# Altium schematic sheet PicoEco_top.SchDoc
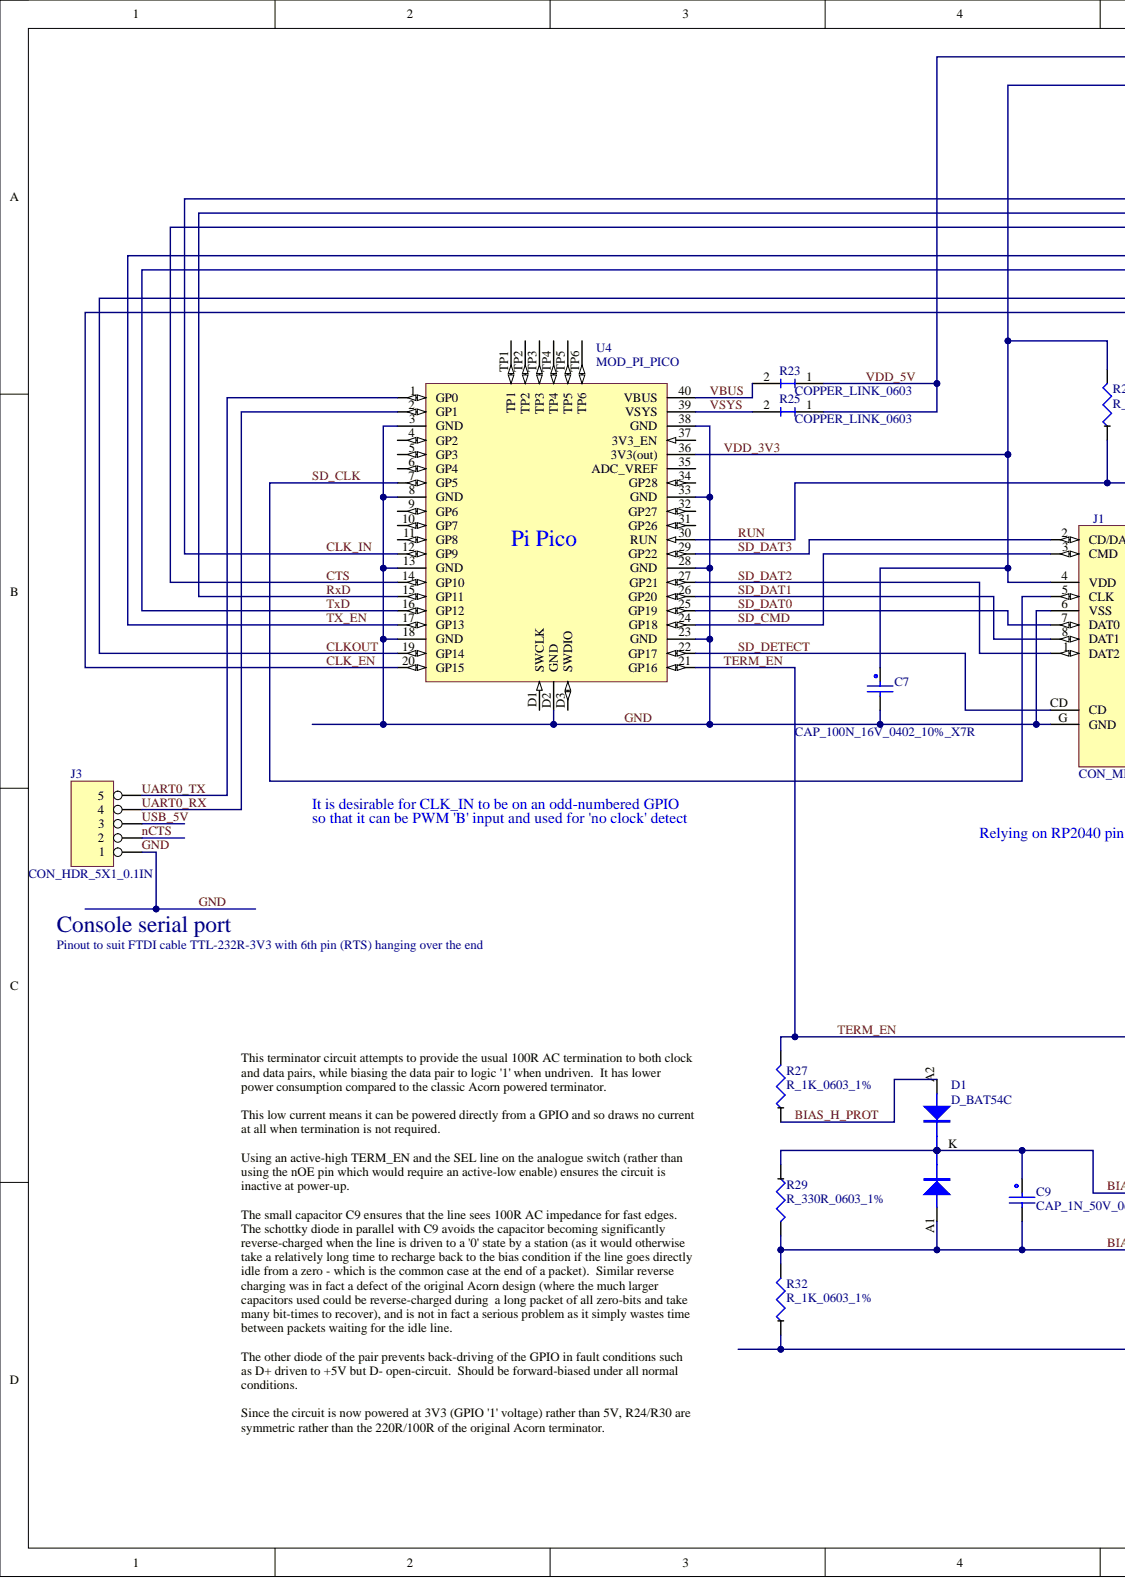
[diagram p0: PicoEco_top.SchDoc, left]
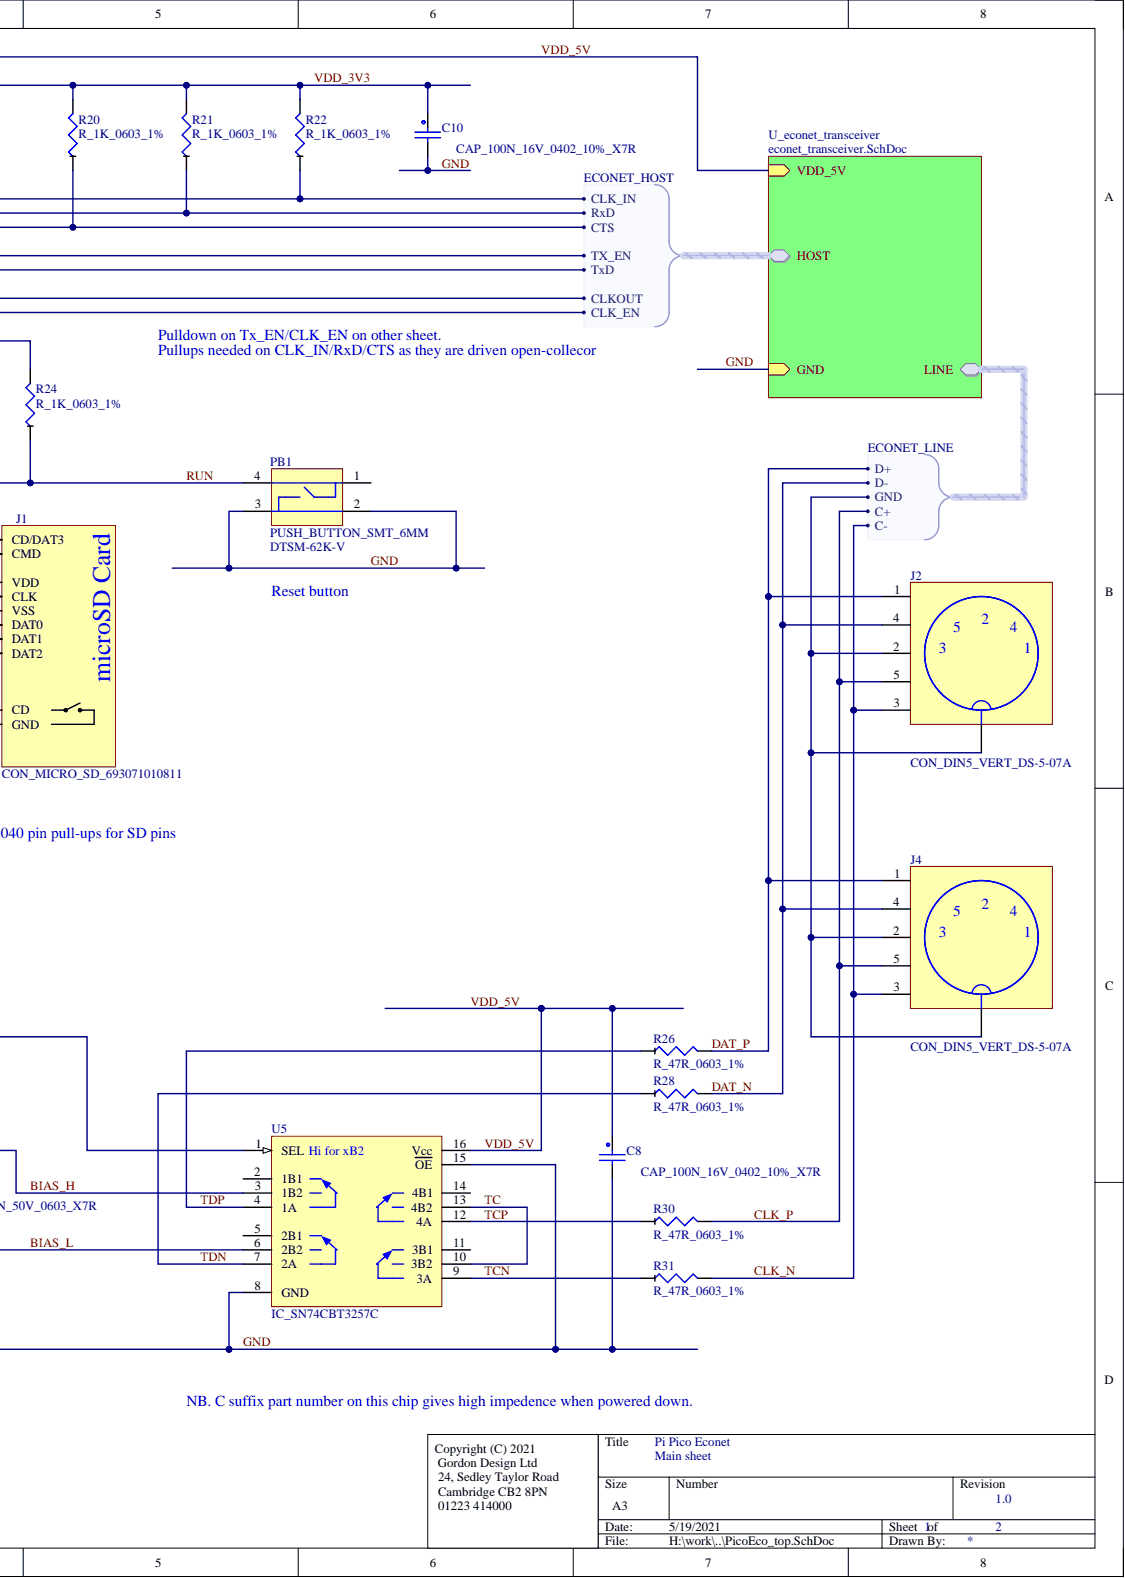
[diagram p1: PicoEco_top.SchDoc, right]

## Parts
C7  CAP_100N_16V_0402_10%_X7R  [C_0402]
C8  CAP_100N_16V_0402_10%_X7R  [C_0402]
C9  CAP_1N_50V_0603_X7R  [C_0603]
C10  CAP_100N_16V_0402_10%_X7R  [C_0402]
D1  D_BAT54C  [SOT23_BAT54C]
J1  CON_MICRO_SD_693071010811  [CON_MICROSD_693071020811]  (value not drawn)
J2  CON_DIN5_VERT_DS-5-07A  [CON_DIN5_VERT_COMBO3]
J3  CON_HDR_5X1_0.1IN  [CON_HDR_5X1]
J4  CON_DIN5_VERT_DS-5-07A  [CON_DIN5_VERT_COMBO3]
PB1  PUSH_BUTTON_SMT_6MM  PARTNO: DTSM-62K-V  [PUSH_BUTTON_SMT_6MM_DTSM-62K]
R20  R_1K_0603_1%  [R_0603]
R21  R_1K_0603_1%  [R_0603]
R22  R_1K_0603_1%  [R_0603]
R23  COPPER_LINK_0603  [COPPER_LINK_0603]  (value not drawn)
R24  R_1K_0603_1%  [R_0603]
R25  COPPER_LINK_0603  [COPPER_LINK_0603]  (value not drawn)
R26  R_47R_0603_1%  [R_0603]
R27  R_1K_0603_1%  [R_0603]
R28  R_47R_0603_1%  [R_0603]
R29  R_330R_0603_1%  [R_0603]
R30  R_47R_0603_1%  [R_0603]
R31  R_47R_0603_1%  [R_0603]
R32  R_1K_0603_1%  [R_0603]
U4  MOD_PI_PICO  [MOD_PI_PICO_SPECIAL]
U5  IC_SN74CBT3257C  [IC_TSSOP16_TI_PKG_PW]

## Nets
BIAS_H: C9.1 D1.K R29.1 U5.3(1B2)
BIAS_H_PROT: D1.A2 R27.1
BIAS_L: C9.2 D1.A1 R29.2 R32.2 U5.6(2B2)
CLK_EN: U4.20(GP15)
CLK_IN: R22.1 U4.12(GP9)
CLK_N: J2.3 J4.3 R31.2
CLK_P: J2.5 J4.5 R30.2
CLKOUT: U4.19(GP14)
CTS: R20.1 U4.14(GP10)
DAT_N: J2.4 J4.4 R28.2
DAT_P: J2.1 J4.1 R26.2
GND: C7.2 C8.2 C10.2 J1.6(VSS) J1.G(GND) J3.1 PB1.2 PB1.3 R32.1 U4.3(GND) U4.8(GND) U4.13(GND) U4.18(GND) U4.23(GND) U4.28(GND) U4.33(GND) U4.38(GND) U4.D2(GND) U5.8(GND) U5.15(OE)
nCTS: J3.2
RUN: PB1.4 R24.1 U4.30(RUN)
RxD: R21.1 U4.15(GP11)
SD_CLK: J1.5(CLK) U4.7(GP5)
SD_CMD: J1.3(CMD) U4.24(GP18)
SD_DAT0: J1.7(DAT0) U4.25(GP19)
SD_DAT1: J1.8(DAT1) U4.26(GP20)
SD_DAT2: J1.1(DAT2) U4.27(GP21)
SD_DAT3: J1.2(CD/DAT3) U4.29(GP22)
SD_DETECT: J1.CD U4.22(GP17)
TC: U5.10(3B2) U5.13(4B2)
TCN: R31.1 U5.9(3A)
TCP: R30.1 U5.12(4A)
TDN: R28.1 U5.7(2A)
TDP: R26.1 U5.4(1A)
TERM_EN: R27.2 U4.21(GP16) U5.1(SEL_Hi_for_xB2)
TX_EN: U4.17(GP13)
TxD: U4.16(GP12)
UART0_RX: J3.4 U4.2(GP1)
UART0_TX: J3.5 U4.1(GP0)
USB_5V: J3.3
VBUS: R23.2 U4.40(VBUS)
VDD_3V3: C7.1 C10.1 J1.4(VDD) R20.2 R21.2 R22.2 R24.2 U4.36(3V3(out))
VDD_5V: C8.1 R23.1 R25.1 U5.16(Vcc)
VSYS: R25.2 U4.39(VSYS)
NetJ2_2: J2.2 J2.SHLD J4.2 J4.SHLD
NetPB1_1: PB1.1
NetU4_4: U4.4(GP2)
NetU4_5: U4.5(GP3)
NetU4_6: U4.6(GP4)
NetU4_9: U4.9(GP6)
NetU4_10: U4.10(GP7)
NetU4_11: U4.11(GP8)
NetU4_31: U4.31(GP26)
NetU4_32: U4.32(GP27)
NetU4_34: U4.34(GP28)
NetU4_35: U4.35(ADC_VREF)
NetU4_37: U4.37(3V3_EN)
NetU4_D1: U4.D1(SWCLK)
NetU4_D3: U4.D3(SWDIO)
NetU4_TP1: U4.TP1
NetU4_TP2: U4.TP2
NetU4_TP3: U4.TP3
NetU4_TP4: U4.TP4
NetU4_TP5: U4.TP5
NetU4_TP6: U4.TP6
NetU5_2: U5.2(1B1)
NetU5_5: U5.5(2B1)
NetU5_11: U5.11(3B1)
NetU5_14: U5.14(4B1)

## Library data of the parts
C7 C8 C10 = CAP_100N_16V_0402_10%_X7R: Capacitor 100nF 16V, 0603, X7R dielectric, 10% tolerance; MANUF: TDK; PARTNO: CGA2B1X7R1C104K050BC; PARTNO_NOTES: Generic part - other manuf acceptable; RS: 723-5200P; Component Kind: Standard; Digikey: 445-5613-1-ND; FARNELL: 2310530; Mouser: 810-CGA2B1X7R1C104K; Library Name: Arbury_C.SchLib
C9 = CAP_1N_50V_0603_X7R: Capacitor, 1nF 50V, X7R dielectric, 20% tolerance, 0603 footprint; Manuf: Kemet; PARTNO: C0603C102M5RACTU; Partno_notes: Generic part - other manuf acceptable; Component Kind: Standard; Digikey: 399-7837-1-ND; Farnell: 2904635; Mouser: 80-C0603C102M5R; Library Name: ARG_SCH.SCHLIB
D1 = D_BAT54C: SMD Diode Dual Schottky 30V 200mA; MANUF: NXP; PARTNO: BAT54C,215; PARTNO_NOTES: Generic part, other manuf acceptable; Component Kind: Standard; Digikey: 1727-2902-1-ND; Farnell: 1081192; Mouser: 771-BAT54C-T/R; Library Name: ARG_SCH.SCHLIB
J1 = CON_MICRO_SD_693071020811: MicroSD card connector push-push, Wurth 693071020811; RS: 201-8977; Component Kind: Standard; Digikey: 732-693071020811CT-ND; FARNELL: 3373676; MANUF: Wurth; Mouser: 710-693071020811; PARTNO: 693071020811; Library Name: ARG_SCH.SCHLIB
J2 J4 = CON_DIN5_VERT_DS-5-07A: 5-pin DIN plug, Vertical PCB-mount.  DS-5-07A from various manufacturers; MANUF: KLS; PARTNO: KLS1-DS-5-07A; PARTNO_NOTES: Really want "TRS Type 2601"; Component Kind: Standard; Library Name: ARG_SCH.SCHLIB
J3 = CON_HDR_5X1_0.1IN: 5 PIN HEADER; Component Kind: Standard; Farnell: 9731105; Library Name: Arbury_CON.SchLib
PB1 = PUSH_BUTTON_SMT_6MM_DTSM-62K: Push button SMT 6mm square body 5mm height standard stiffness (black button); MANUF: DIPTRONICS; Component Kind: Standard; Digikey: ADTSM62KVTR-ND; Mouser: 113-DTSM-62K-V-T/R; Library Name: ARG_SCH.SCHLIB
R20-R22 R24 R27 R32 = R_1K_0603_1%: Resistor, 1K, 0603, 1% tolerance; MANUF: Walsin; PARTNO: WR06X1001FTL; PARTNO_NOTES: Generic part - other manuf acceptable; Component Kind: Standard; Digikey: 1292-WR06X1001FTLCT-ND; FARNELL: 2446589; Mouser: 791-WR06X1001FTL; Library Name: Arbury_R.SchLib
R23 R25 = COPPER_LINK_0603: Made-in-copper link with 0603 pads; PARTNO_NOTES: No part to be fitted here unless PCB track cut; Component Kind: Net Tie; NOFIT: NOFIT; Library Name: ARG_SCH.SCHLIB
R26 R28 R30 R31 = R_47R_0603_1%: Resistor, 47R, 0603,1% tolerance; Manuf: Walsin; PARTNO: WR06X47R0FTL; PARTNO_NOTES: Generic part - other manuf acceptable; Component Kind: Standard; Digikey: 541-5440-1-ND; Farnell: 2446695; Mouser: 791-WR06X47R0FTL; Library Name: Arbury_R.SchLib
R29 = R_330R_0603_1%: Resistor, 330R, 0603,1% tolerance; MANUF: Walsin; PARTNO: WR06X3300FTL; PARTNO_NOTES: Generic part - other manuf acceptable; Component Kind: Standard; Digikey: 1292-WR06X3300FTLCT-ND; FARNELL: 2446656; Mouser: 791-WR06X3300FTL; Library Name: Arbury_R.SchLib
U4 = MOD_PI_PICO: Raspberry Pi Pico module (RP2040); PARTNO: SC0915; Component Kind: Standard; MANUF: Raspberry Pi; Library Name: ARG_SCH.SCHLIB
U5 = IC_SN74CBT3257C: Quad SPDT analogue switch.  Note 'C' suffix to part number - gives powerdown isolation; Manuf: TI; PARTNO: SN74CBT3257CPWR; PARTNON_NOTES: Must be exact part - no alternates; Component Kind: Standard; Digikey: 296-19211-1-ND; Mouser: 595-SN74CBT3257CPWR; Library Name: ARG_SCH.SCHLIB
